# Altium schematic sheet LineFollower_2-0.SchDoc
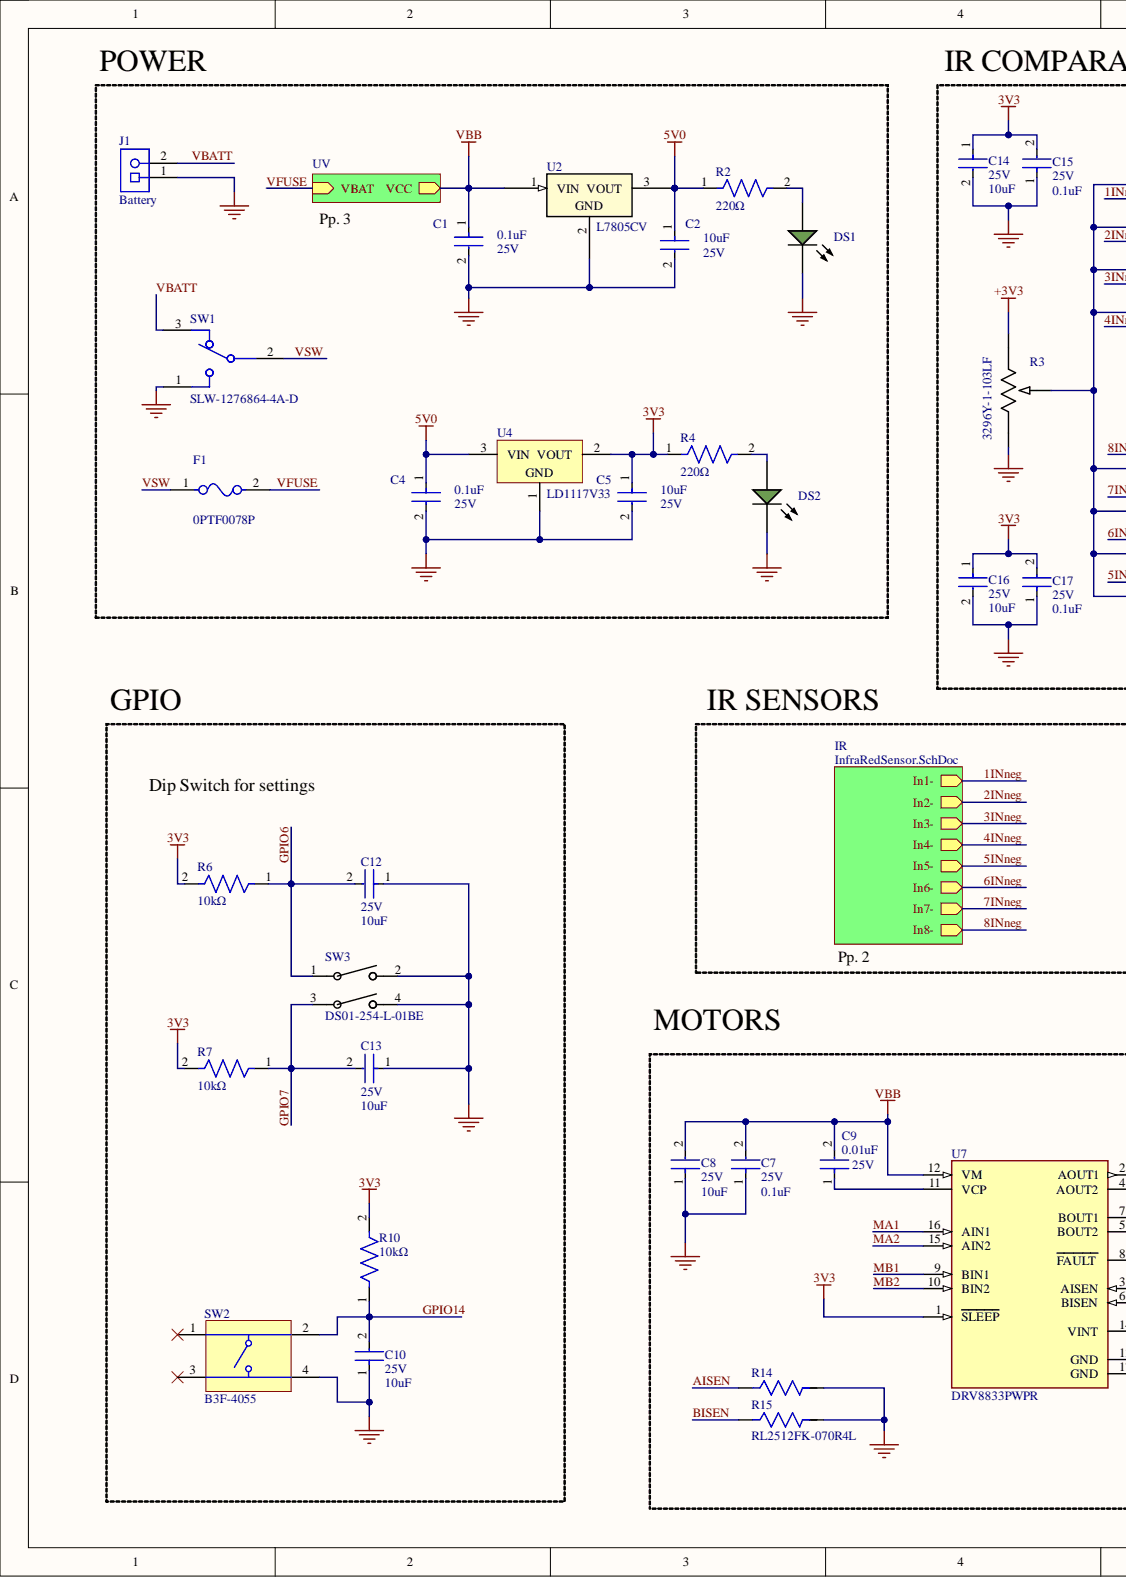
[diagram p0: LineFollower_2-0.SchDoc, left]
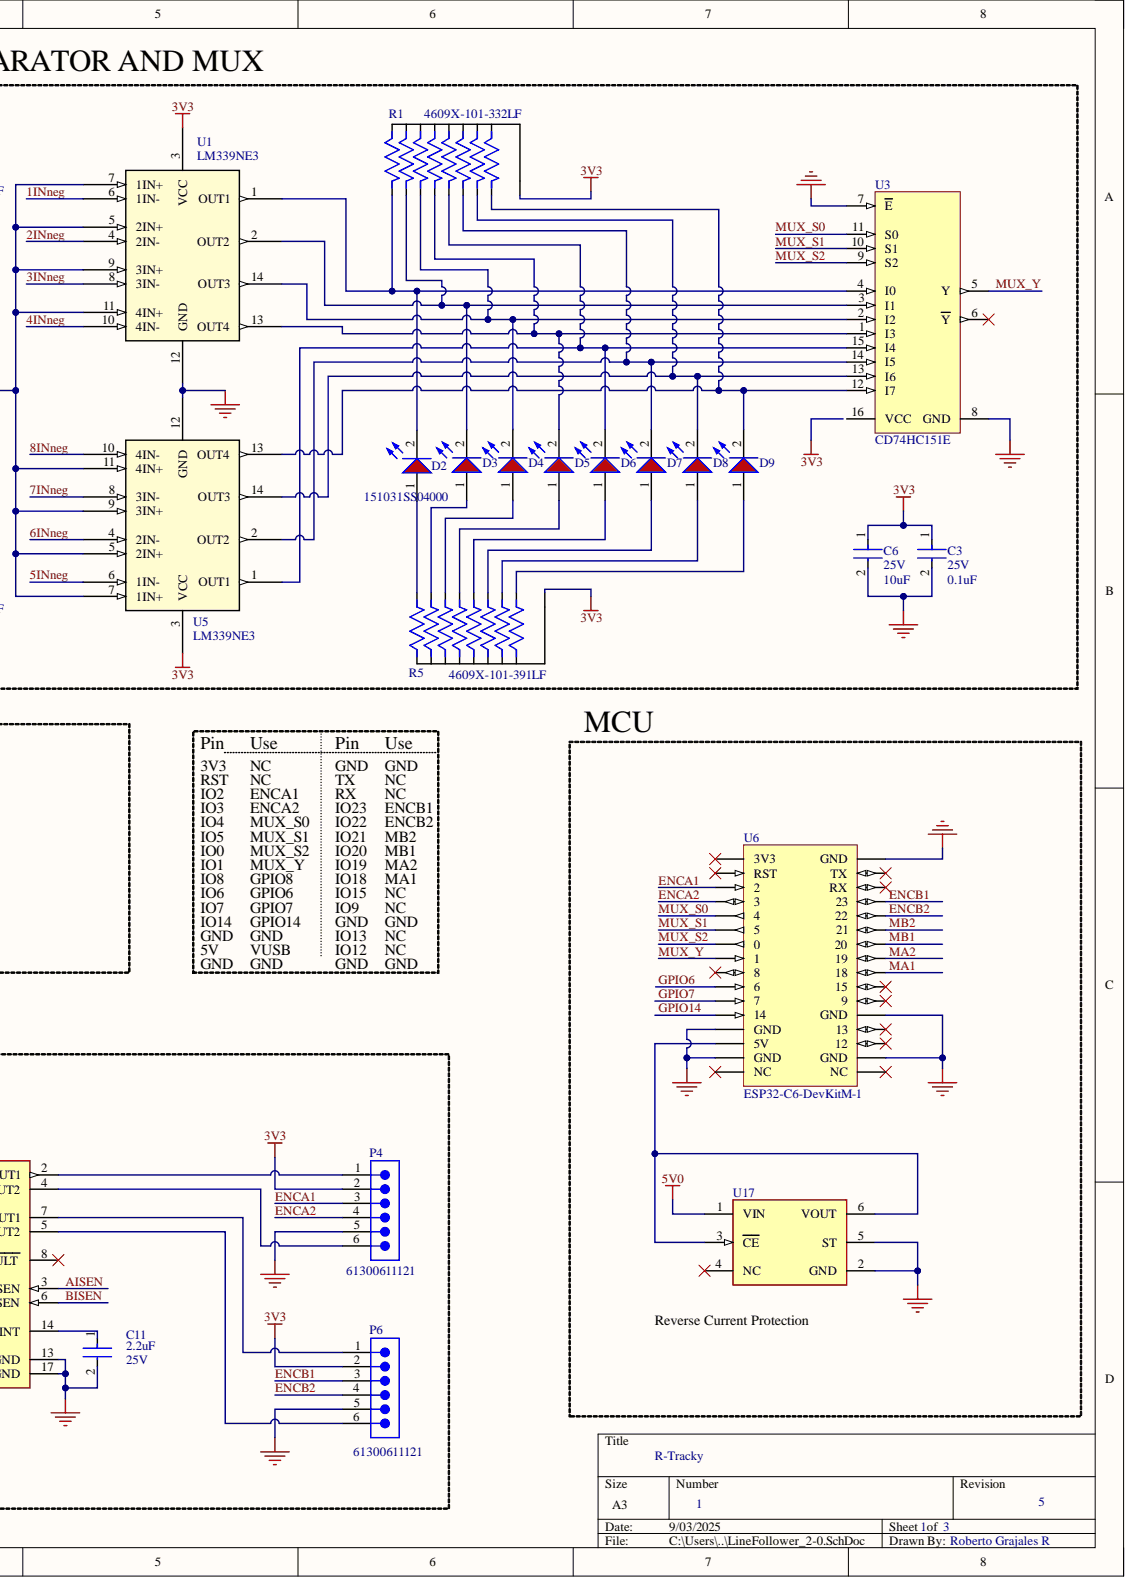
[diagram p1: LineFollower_2-0.SchDoc, right]

## Parts
C1  RCER71E106K3K1H03B  [FP-RCE3M1-MFG]  (value not drawn)
C2  Capacitance: 10uF  Voltage Rating: 25V  [FP-RCE3M1-MFG]  (hidden comment: RCER71E106K3K1H03B)
C3  Capacitance: 0.1uF  Voltage Rating: 25V  [FP-RCE3M1-MFG]  (hidden comment: RCER71E106K3K1H03B)
C4  RCER71E106K3K1H03B  [FP-RCE3M1-MFG]  (value not drawn)
C5  Capacitance: 10uF  Voltage Rating: 25V  [FP-RCE3M1-MFG]  (hidden comment: RCER71E106K3K1H03B)
C6  Capacitance: 10uF  Voltage Rating: 25V  [FP-RCE3M1-MFG]  (hidden comment: RCER71E106K3K1H03B)
C7  Capacitance: 0.1uF  Voltage Rating: 25V  [FP-RCE3M1-MFG]  (hidden comment: RCER71E106K3K1H03B)
C8  Capacitance: 10uF  Voltage Rating: 25V  [FP-RCE3M1-MFG]  (hidden comment: RCER71E106K3K1H03B)
C9  Capacitance: 0.01uF  Voltage Rating: 25V  [FP-RCE3M1-MFG]  (hidden comment: RCER71E106K3K1H03B)
C10  Capacitance: 10uF  Voltage Rating: 25V  [FP-RCE3M1-MFG]  (hidden comment: RCER71E106K3K1H03B)
C11  Capacitance: 2.2uF  Voltage Rating: 25V  [FP-RCE3M1-MFG]  (hidden comment: RCER71E106K3K1H03B)
C12  Capacitance: 10uF  Voltage Rating: 25V  [FP-RCE3M1-MFG]  (hidden comment: RCER71E106K3K1H03B)
C13  Capacitance: 10uF  Voltage Rating: 25V  [FP-RCE3M1-MFG]  (hidden comment: RCER71E106K3K1H03B)
C14  Capacitance: 10uF  Voltage Rating: 25V  [FP-RCE3M1-MFG]  (hidden comment: RCER71E106K3K1H03B)
C15  Capacitance: 0.1uF  Voltage Rating: 25V  [FP-RCE3M1-MFG]  (hidden comment: RCER71E106K3K1H03B)
C16  Capacitance: 10uF  Voltage Rating: 25V  [FP-RCE3M1-MFG]  (hidden comment: RCER71E106K3K1H03B)
C17  Capacitance: 0.1uF  Voltage Rating: 25V  [FP-RCE3M1-MFG]  (hidden comment: RCER71E106K3K1H03B)
D2  151031SS04000  [WL-TMRC_D3.95H5.3]
D3  151031SS04000  [WL-TMRC_D3.95H5.3]
D4  151031SS04000  [WL-TMRC_D3.95H5.3]
D5  151031SS04000  [WL-TMRC_D3.95H5.3]
D6  151031SS04000  [WL-TMRC_D3.95H5.3]
D7  151031SS04000  [WL-TMRC_D3.95H5.3]
D8  151031SS04000  [WL-TMRC_D3.95H5.3]
D9  151031SS04000  [WL-TMRC_D3.95H5.3]
DS1  LTL-1CHG  [LTST-LTL-1CHG-2]  (value not drawn)
DS2  LTL-1CHG  [LTST-LTL-1CHG-2]  (value not drawn)
F1  0PTF0078P  [FP-0PTF0078P-MFG]
J1  Battery  [PHOE-1729128-2_V]
P4  61300611121  [61300611121]
P6  61300611121  [61300611121]
R1  4609X-101-332LF  [BO_4609X]
R2  value 220Ω  [MyRes]  (hidden comment: 0.5 W Resistor)
R3  3296Y-1-103LF  [POT_3296Y]
R4  value 220Ω  [MyRes]  (hidden comment: 0.5 W Resistor)
R5  4609X-101-391LF  [BO_4609X]
R6  value 10kΩ  [MyRes]  (hidden comment: 0.5 W Resistor)
R7  value 10kΩ  [MyRes]  (hidden comment: 0.5 W Resistor)
R10  value 10kΩ  [MyRes]  (hidden comment: 0.5 W Resistor)
R14  RL2512FK-070R4L  [RES_2512_WSL-M]
R15  RL2512FK-070R4L  [RES_2512_WSL-M]
SW1  SLW-1276864-4A-D  [SLW-1276864_CUD]
SW2  B3F-4055  [OMRON-B3F-4055_V]
SW3  DS01-254-L-01BE  [SW_DS01-254-L-01BE_CUD]
U1  LM339NE3  [N14]
U2  L7805CV  [TO-220_STM]
U3  CD74HC151E  [N0016A]
U4  LD1117V33  [TO220]
U5  LM339NE3  [N14]
U6  ESP32-C6-DevKitM-1  [ESP32-C6-DevKitC-1]
U7  DRV8833PWPR  [FP-PWP0016C-IPC_A]
U17  LM66100DCKT  [FP-DCK0006A-IPC_C]  (value not drawn)

## Nets
3V3: C3.1 C5.1 C6.1 C14.1 C15.2 C16.1 C17.2 P4.2 P6.2 R1.1 R4.1 R5.1 R6.2 R7.2 R10.2 U1.3(VCC) U4.2 U5.3(VCC) U7.1(SLEEP)
5V0: C2.1 C4.1 R2.1 U2.3 U4.3 U17.1(VIN)
GND: C1.2 C2.2 C3.2 C4.2 C5.2 C6.2 C7.1 C8.1 C10.1 C11.2 C12.1 C13.1 C14.2 C15.1 C16.2 C17.1 DS1.2 DS2.2 J1.1 P4.5 P6.5 R3.3 R14.2 R15.2 SW1.1 SW2.4 SW3.2 SW3.4 U1.12(GND) U2.2 U3.7(E) U3.8(GND) U4.1 U5.12(GND) U6.13(GND) U6.15(GND) U6.18(GND) U6.21(GND) U6.32(GND) U7.13(GND) U7.17(GND) U17.2(GND) U17.5(ST)
VBB: C1.1 C7.2 C8.2 C9.2 U2.1 U7.12(VM)
1INneg: U1.6(1IN-)
2INneg: U1.4(2IN-)
3INneg: R3.1 U1.8(3IN-)
4INneg: U1.10(4IN-)
5INneg: U5.6(1IN-)
6INneg: U5.4(2IN-)
7INneg: U5.8(3IN-)
8INneg: U5.10(4IN-)
AISEN: R14.1 U7.3(AISEN)
BISEN: R15.1 U7.6(BISEN)
ENCA1: P4.3 U6.3
ENCA2: P4.4 U6.4
ENCB1: P6.3 U6.29
ENCB2: P6.4 U6.28
GPIO6: C12.2 R6.1 SW3.1 U6.10
GPIO7: C13.2 R7.1 SW3.3 U6.11
GPIO14: C10.2 R10.1 SW2.2 U6.12
MA1: U6.24 U7.16(AIN1)
MA2: U6.25 U7.15(AIN2)
MB1: U6.26 U7.9(BIN1)
MB2: U6.27 U7.10(BIN2)
MUX_S0: U3.11(S0) U6.5
MUX_S1: U3.10(S1) U6.6
MUX_S2: U3.9(S2) U6.7
MUX_Y: U3.5(Y) U6.8
VBATT: J1.2 SW1.3
VFUSE: F1.2
VSW: F1.1 SW1.2
NetC9_1: C9.1 U7.11(VCP)
NetC11_1: C11.1 U7.14(VINT)
NetD2_1: D2.1 R5.9
NetD2_2: D2.2 R1.9 U1.1(OUT1) U3.4(I0)
NetD3_1: D3.1 R5.8
NetD3_2: D3.2 R1.8 U1.2(OUT2) U3.3(I1)
NetD4_1: D4.1 R5.7
NetD4_2: D4.2 R1.7 U1.14(OUT3) U3.2(I2)
NetD5_1: D5.1 R5.6
NetD5_2: D5.2 R1.6 U1.13(OUT4) U3.1(I3)
NetD6_1: D6.1 R5.5
NetD6_2: D6.2 R1.5 U3.15(I4) U5.1(OUT1)
NetD7_1: D7.1 R5.4
NetD7_2: D7.2 R1.4 U3.14(I5) U5.2(OUT2)
NetD8_1: D8.1 R5.3
NetD8_2: D8.2 R1.3 U3.13(I6) U5.14(OUT3)
NetD9_1: D9.1 R5.2
NetD9_2: D9.2 R1.2 U3.12(I7) U5.13(OUT4)
NetDS1_1: DS1.1 R2.2
NetDS2_1: DS2.1 R4.2
NetP4_1: P4.1 U7.2(AOUT1)
NetP4_6: P4.6 U7.4(AOUT2)
NetP6_1: P6.1 U7.7(BOUT1)
NetP6_6: P6.6 U7.5(BOUT2)
NetR3_2: R3.2 U1.5(2IN+) U1.7(1IN+) U1.9(3IN+) U1.11(4IN+) U5.5(2IN+) U5.7(1IN+) U5.9(3IN+) U5.11(4IN+)
NetSW2_1: SW2.1
NetSW2_3: SW2.3
NetU3_6: U3.6(Y)
NetU3_16: U3.16(VCC)
NetU6_1: U6.1(3V3)
NetU6_2: U6.2(RST)
NetU6_9: U6.9
NetU6_14: U6.14(5V) U17.3(CE) U17.6(VOUT)
NetU6_16: U6.16(NC)
NetU6_17: U6.17(NC)
NetU6_19: U6.19
NetU6_20: U6.20
NetU6_22: U6.22
NetU6_23: U6.23
NetU6_30: U6.30(RX)
NetU6_31: U6.31(TX)
NetU7_8: U7.8(FAULT)
NetU17_4: U17.4(NC)

## Library data of the parts
C1 C5 C7 C9 C11 = CMP-06035-054025-1: Cap Ceramic 0.1uF 25V X7R ±10% Radial 5mm +125°C Automotive Ammo Pack; Lead Diameter: 0.5mm; Lead/Base Style: Formed Leads; Case/Package: Radial; Component Kind: Standard; Dielectric: X7R; Height: 5mm; Height - Seated (Max): 0.197inch; Lead Pitch: 0.197inch; Length: 0.217inch; Library Name: Altium Content Vault; Max Operating Temperature: 125°C; Min Operating Temperature: -55°C; Mount: Through Hole; Package Quantity: 500; Packaging: Bulk; Ratings: AEC-Q200; Resistor Type: Ceramic; Termination: Radial; Tolerance: 10%; Voltage Rating (DC): 25V; Width: 4mm
  C1: Capacitance: 0.1uF; Voltage Rating: 25V
C2 C3 C6 C8 C10 C12-C17 = CMP-06035-054025-1: Cap Ceramic 10uF 25V X7R ±10% Radial 5mm +125°C Automotive Ammo Pack; Lead Diameter: 0.5mm; Lead/Base Style: Formed Leads; Case/Package: Radial; Component Kind: Standard; Dielectric: X7R; Height: 5mm; Height - Seated (Max): 0.197inch; Lead Pitch: 0.197inch; Length: 0.217inch; Library Name: Altium Content Vault; Max Operating Temperature: 125°C; Min Operating Temperature: -55°C; Mount: Through Hole; Package Quantity: 500; Packaging: Bulk; Ratings: AEC-Q200; Resistor Type: Ceramic; Termination: Radial; Tolerance: 10%; Voltage Rating (DC): 25V; Width: 4mm
C4 = CMP-06035-054025-1: Cap Ceramic 0.15uF 25V X7R ±10% Radial 5mm +125°C Automotive Ammo Pack; Capacitance: 0.1uF; Lead Diameter: 0.5mm; Lead/Base Style: Formed Leads; Case/Package: Radial; Component Kind: Standard; Dielectric: X7R; Height: 5mm; Height - Seated (Max): 0.197inch; Lead Pitch: 0.197inch; Length: 0.217inch; Library Name: Altium Content Vault; Max Operating Temperature: 125°C; Min Operating Temperature: -55°C; Mount: Through Hole; Package Quantity: 500; Packaging: Bulk; Ratings: AEC-Q200; Resistor Type: Ceramic; Termination: Radial; Tolerance: 10%; Voltage Rating: 25V; Voltage Rating (DC): 25V; Width: 4mm
D2-D9 = CMP-1488-00005-2: NexarPartId: 29717802; Color: Red; Dominant Wavelength: 635nm; Forward Current: 100mA; Forward Voltage: 2V; Lens Size: 3mm; Lens Style: Circular, Diffused, Round; Luminous Intensity: 160mcd; Max Reverse Voltage (DC): 5V; Peak Wavelength: 640nm; Test Current: 20mA; Viewing Angle: 40°; Case/Package: Radial; Component Kind: Standard; Height: 5.3mm; Length: 3mm; Library Name: Altium Content Vault; Max Operating Temperature: 85°C; Min Operating Temperature: -40°C; Mount: Through Hole; Packaging: Bulk; REACH SVHC: Unknown; RoHS: Compliant; Wavelength: 635nm; Width: 3mm
DS1 DS2 = CMP-1674-00014-1: LITE-ON Electronic, INC, 120 mA, 100 mW, 5 V, -55 to 100 degC, 2-Pin THD, RoHS, Bulk; Case\Package: QFN; Interface: I2C; Max Supply Voltage: 3.6 V; Min Supply Voltage: 2.5 V; Component Kind: Standard; ComponentLink1Description: Manufacturer URL; ComponentLink1URL: http://www.us.liteon.com/; ComponentLink2Description: Datasheet; ComponentLink2URL: http://datasheet.octopart.com/LTL-1CHG-Lite-On-datasheet-143841.pdf; DatasheetVersion: Mar-00; Library Name: Altium Content Vault; Max Operating Temperature: 85 degC; Min Operating Temperature: -40 degC; Package Quantity: 5000; PackageDescription: 2-Pin Through Hole Device, Dia 3.8 mm; PackageReference: LTL-1CHG; Packaging: Cut Tape; Pins: 24; REACH SVHC: No SVHC; RoHSCompliant: true
F1 = CMP-08604-000023-1: FUSE BLOCK CARTRIDGE PCB; Manufacturer: Littelfuse Inc; Conn Orientation: Vertical; Fuse Current: 6.3A; Number Of Fuses: 1; Voltage Rating Ac: 250V; Ambient Temperature Range High: +85°C; Ambient Temperature Range Low: -30°C; Automotive: No; Category: Fuse; Component Kind: Standard; ComponentLink2Description: Datasheet; ComponentLink2URL: https://media.digikey.com/pdf/Data%20Sheets/Littelfuse%20PDFs/PTF075_77_78.pdf; Device Class L1: Circuit Protection; Device Class L2: Fuse Holders; Device Class L3: unset; Digikey Description: FUSE BLOCK CARTRIDGE PCB; Height: 11.5mm; Lead Free: Yes; Library Name: Altium Content Vault; Manufacturer Part Number: 0PTF0078P; Mouser Description: Fuse Holder 5X20MM Pitch 22/7 UL94V0 Open; Octopart: https://octopart.com/0ptf0078p-littelfuse-13255855; Package: 0; RoHS: TRUE; Voltage Rating Dc: 250V
J1 = CMP-2000-05815-1: PCB Terminal Block, 13.5 A, 400 V, 2-Pin THD, RoHS, Bulk; Manufacturer: Phoenix Contact; PartNumber: 1729128; Current Rating: 13500mA; No. of Positions: 2; No. of Rows: 1; Package Version: 03/2015; Pitch: 5.08mm; Voltage Rating: 400V; Component Kind: Standard; Datasheet URL: https://datasheet.ciiva.com/16046/1729128-16046181.pdf; Datasheet Version: 12/2013; Library Name: Altium Content Vault; Manufacturer URL: http://www.phoenixcontact.com/; Mounting Technology: ThroughHole; Orientation: Horizontal; Package Description: 2-Pin Through Hole Device, Body 8.1 x 10.16 mm, Pitch 5.08 mm; Package Reference: 1729128-2; Package URL: https://www.phoenixcontact.com/online/portal/pi/pxc/product_detail_page/!ut/p/b1/3VdJjqNKFDxLH8AFycySyWY2ozFsEPOMMQaDOX1XedGL_1Vdm5YXJleknhSKeBkvI6EA8ncAo3AYoDhBQGco6KN7VURTdemj9us_IEKEZo_YHiPAEWEFmDGOHMORkqKYCORBZxgL7ZoaZYcbFFUZ3fqxSY6GOuxydWUuHqz1ynmMFygu583Bob0Ewr6Sd_l-5m-kAueHiB0jpRwZwe58wwtkpxtMYd_LmGcDRU02dRNEyWt6Y2ti5pSyJ_reIkzIulrqApdnbT11V5jnCKbYNCvhEM1MCpPByat_X379-iThf08CI5GfOPqfBeS3BRoBOdD55AKVv0iLwCMq-2BrzXWSGRH7pUGD9uwXQjiph3xL0DKQwdGa0JImiIOmBNOestqgwTHSSs2oOep-78apyXQBvNi8ZLaFjvjWvcaUEBu0MC6Wbe-d6mB0FAebo2lUWinqYaZs5DAYzV0RP9yitUjnGJ0iI9xhZkT42OCQNUJJhkgWINNQLP2JFP6OpMg3JCVg70jqHT0lvKOnhHf0lPuOnnLf0VPuWx6_dxwUIvwk5WMkT0kLL1mh61l2yZVSzG3pYV_bqo-mj6uMKwKwdnnW8Jh5RTOJzMOA5c-eJDaZQw4mTMPH02PvS_ZAqNVjVTo2WyYLkwH_KU1mmmG5kjRhDqPXLwwVHvVOjCM60-fpXvTDfMGW1PVFVS1r4e4yVDk4ZdNbkUHvqPqQSeian4UD39fNKmachqdJ1NJbyk8rXT9mXTvPk3oE5meulKGgiruPJek-4I9hTT6G8ZLOyRSm2RRVbThERQad5OS28hdt4YW4vG0stgYYzsWsK4_7y6DZMjJSCqri1kyiZR5vD7pwIrNR7QwPHTcLTI5qT7eRlpHrdGiupYlqQDJ7zy_CND8fWWBoLRXxk1RVcXiBQwbTD4Xi7KL-FuO94t9xaqweXzH; Packing: Bulk; RoHS: TRUE
P4 P6 = CMP-1502-01070-3: NexarPartId: 20084590; Connector Type: Male Pin; Contact Resistance: 20mΩ; Current Rating: 3A; Gender: Male; Number of Contacts: 6; Operating Supply Voltage: 250V; Pitch: 2.54mm; Color: Black; Component Kind: Standard; Contact Gender: Male; Contact Material: Copper; Contact Mating Length: 5.9944mm; Contact Plating: Gold; Height: 8.89mm; Housing Material: PBT; Lead Free: Lead Free; Lead Pitch: 2.54mm; Library Name: Altium Content Vault; Max Operating Temperature: 105°C; Min Operating Temperature: -40°C; Mount: Through Hole; Number of Pins: 6; Number of Positions: 6; Number of Rows: 1; Orientation: Vertical; Packaging: Bulk; REACH SVHC: Unknown; RoHS: Compliant; Termination: Through Hole; Voltage Rating: 250V
R1 = 4609X-101-101LF: Array/Network Resistor, Bussed, Metal Glaze/thick Film, 1.13W, 100ohm, 100V, 2% +/-Tol, 100ppm/Cel, Through Hole Mount, 9010; Manufacturer: Bourns; Manufacturer Series: 4600X; Manufacturer_Name: Bourns Electronics; Channels: 1; Element Power Dissipation: 200mW; First Element Resistance: 100R; Lead Length: 3.3mm; Number of Elements: 8; Package Height: 8.89mm; Package Length: 22.81mm; Package Width: 2.49mm; Rated Temperature: 70°C; Temperature Coefficient (ppm/Cel): 100; Temperature Coefficient Tracking: 50ppm/°C; Value: 3k3R; Case/Package: 9010; Category: Resistor Arrays; Component Kind: Standard; ComponentLink1Description: https://datasheet.ciiva.com:443/pdfs/VipMasterIC/IC/BOUR/BOUR-S-A0008940916/BOUR-S-A0008940916-1.pdf?src-supplier=IHS+Markit; ComponentLink1URL: https://datasheet.ciiva.com:443/pdfs/VipMasterIC/IC/BOUR/BOUR-S-A0008940916/BOUR-S-A0008940916-1.pdf?src-supplier=IHS+Markit; ComponentLink2Description: https://datasheet.ciiva.com/11807/0900766b8069ccfd-11807166.pdf?src-supplier=Rs; ComponentLink2URL: https://datasheet.ciiva.com/11807/0900766b8069ccfd-11807166.pdf?src-supplier=Rs; ComponentLink3Description: https://datasheet.ciiva.com/30195/4600x-30195886.pdf?src-supplier=Onlinecomponents.com; ComponentLink3URL: https://datasheet.ciiva.com/30195/4600x-30195886.pdf?src-supplier=Onlinecomponents.com; ComponentLink4Description: https://datasheet.ciiva.com/14179/ee4609x-14179631.pdf?src-supplier=Distrelec; ComponentLink4URL: https://datasheet.ciiva.com/14179/ee4609x-14179631.pdf?src-supplier=Distrelec; ComponentLink5Description: https://datasheet.ciiva.com/1133/682196-1133199.pdf?src-supplier=Element14; ComponentLink5URL: https://datasheet.ciiva.com/1133/682196-1133199.pdf?src-supplier=Element14; Construction: Epoxy Resin; JESD-609 Code: e1; Lead Pitch: 2.54mm; Library Name: Schlib1.SchLib; Manufacturer Part Number: 4609X-101-101LF; Manufacturer_Part_Number: 4609X-101-101LF; Max Operating Temperature: 125°C; Min Operating Temperature: -55°C; Mounting Technology: Through Hole; Network Type: BUSSED; Package Shape: Rectangular; Packaging: Bulk; Pins: 9; Power: 1.13W; Pricing: 1=0.44, 10=0.28, 25=0.2372, 50=0.2104, 200=0.16815, 400=0.15163, 600=0.14315, 1000=0.13354, 5000=0.10982 (USD); Reach SVHC Compliant: Yes; Resistor Type: ARRAY/NETWORK RESISTOR; RoHS: Yes; RoHS Compliant: Yes; Series: 4600X; Stock: 1993; Supplier: Digikey; Supplier Part Number: 4609X-101-101LF-ND; Technology: METAL GLAZE/THICK FILM; Terminal Finish: Copper; Termination: Flat; Tolerance: 2%; Working Voltage: 100V; Copyright: Copyright (C) 2025 Ultra Librarian. All rights reserved.
R2 R4 R6 R7 R10 = RES: Watts: 0.5; Component Kind: Standard; Library Name: Schlib1.SchLib
R3 = 3296Y-1-103LF: No Description Available; Manufacturer_Name: Bourns Electronics; Component Kind: Standard; Library Name: Schlib1.SchLib; Manufacturer_Part_Number: 3296Y-1-103LF; Copyright: Copyright (C) 2025 Ultra Librarian. All rights reserved.
R5 = 4609X-101-101LF: Array/Network Resistor, Bussed, Metal Glaze/thick Film, 1.13W, 100ohm, 100V, 2% +/-Tol, 100ppm/Cel, Through Hole Mount, 9010; Manufacturer: Bourns; Manufacturer Series: 4600X; Manufacturer_Name: Bourns Electronics; Channels: 1; Element Power Dissipation: 200mW; First Element Resistance: 100R; Lead Length: 3.3mm; Number of Elements: 8; Package Height: 8.89mm; Package Length: 22.81mm; Package Width: 2.49mm; Rated Temperature: 70°C; Temperature Coefficient (ppm/Cel): 100; Temperature Coefficient Tracking: 50ppm/°C; Value: 180R; Case/Package: 9010; Category: Resistor Arrays; Component Kind: Standard; ComponentLink1Description: https://datasheet.ciiva.com:443/pdfs/VipMasterIC/IC/BOUR/BOUR-S-A0008940916/BOUR-S-A0008940916-1.pdf?src-supplier=IHS+Markit; ComponentLink1URL: https://datasheet.ciiva.com:443/pdfs/VipMasterIC/IC/BOUR/BOUR-S-A0008940916/BOUR-S-A0008940916-1.pdf?src-supplier=IHS+Markit; ComponentLink2Description: https://datasheet.ciiva.com/11807/0900766b8069ccfd-11807166.pdf?src-supplier=Rs; ComponentLink2URL: https://datasheet.ciiva.com/11807/0900766b8069ccfd-11807166.pdf?src-supplier=Rs; ComponentLink3Description: https://datasheet.ciiva.com/30195/4600x-30195886.pdf?src-supplier=Onlinecomponents.com; ComponentLink3URL: https://datasheet.ciiva.com/30195/4600x-30195886.pdf?src-supplier=Onlinecomponents.com; ComponentLink4Description: https://datasheet.ciiva.com/14179/ee4609x-14179631.pdf?src-supplier=Distrelec; ComponentLink4URL: https://datasheet.ciiva.com/14179/ee4609x-14179631.pdf?src-supplier=Distrelec; ComponentLink5Description: https://datasheet.ciiva.com/1133/682196-1133199.pdf?src-supplier=Element14; ComponentLink5URL: https://datasheet.ciiva.com/1133/682196-1133199.pdf?src-supplier=Element14; Construction: Epoxy Resin; JESD-609 Code: e1; Lead Pitch: 2.54mm; Library Name: Schlib1.SchLib; Manufacturer Part Number: 4609X-101-101LF; Manufacturer_Part_Number: 4609X-101-101LF; Max Operating Temperature: 125°C; Min Operating Temperature: -55°C; Mounting Technology: Through Hole; Network Type: BUSSED; Package Shape: Rectangular; Packaging: Bulk; Pins: 9; Power: 1.13W; Pricing: 1=0.44, 10=0.28, 25=0.2372, 50=0.2104, 200=0.16815, 400=0.15163, 600=0.14315, 1000=0.13354, 5000=0.10982 (USD); Reach SVHC Compliant: Yes; Resistor Type: ARRAY/NETWORK RESISTOR; RoHS: Yes; RoHS Compliant: Yes; Series: 4600X; Stock: 1993; Supplier: Digikey; Supplier Part Number: 4609X-101-101LF-ND; Technology: METAL GLAZE/THICK FILM; Terminal Finish: Copper; Termination: Flat; Tolerance: 2%; Working Voltage: 100V; Copyright: Copyright (C) 2025 Ultra Librarian. All rights reserved.
R14 R15 = RL2512FK-070R4L: Fixed Resistor, Metal Glaze/thick Film, 1W, 0.4ohm, 1% +/-Tol, -300,300ppm/Cel, 2512; Manufacturer: Yageo; Manufacturer_Name: Vishay; Manufacturer_Part_Number: WSL2512R2500FEA; Package Height: 0.55mm; Package Length: 6.35mm; Package Width: 3.2mm; Value: 400mR; Case/Package: 2512; Category: Chip SMD Resistors; Component Kind: Standard; ComponentLink1Description: https://datasheet.ciiva.com:443/pdfs/VipMasterIC/IC/YAGO/YAGO-S-A0001508779/YAGO-S-A0001508779-1.pdf?src-supplier=IHS+Markit; ComponentLink1URL: https://datasheet.ciiva.com:443/pdfs/VipMasterIC/IC/YAGO/YAGO-S-A0001508779/YAGO-S-A0001508779-1.pdf?src-supplier=IHS+Markit; ComponentLink2Description: http://www.mouser.com/ds/2/447/PYu-RL_521_RoHS_L_5-2927.pdf?src-supplier=Mouser; ComponentLink2URL: http://www.mouser.com/ds/2/447/PYu-RL_521_RoHS_L_5-2927.pdf?src-supplier=Mouser; ComponentLink3Description: http://www.yageo.com/documents/recent/PYu-RL_Group_521_RoHS_L_2.pdf?src-supplier=Digikey; ComponentLink3URL: http://www.yageo.com/documents/recent/PYu-RL_Group_521_RoHS_L_2.pdf?src-supplier=Digikey; Construction: Chip; Datasheet: https://www.vishay.com/doc?30100; JESD-609 Code: e3; Library Name: Schlib1.SchLib; Manufacturer Part Number: RL2512FK-070R4L; Max Operating Temperature: 155°C; Min Operating Temperature: -55°C; Packaging: Tape and Reel; Pins: 2; Power: 1W; Pricing: 1=0.28, 10=0.184, 100=0.1173, 1000=0.097 (USD); Reach SVHC Compliant: Yes; Resistor Type: FIXED RESISTOR; RoHS: Yes; RoHS Compliant: Yes; Series: RL; Stock: 7925; Supplier: Digikey; Supplier Part Number: 13-RL2512FK-070R4LCT-ND; Technology: METAL GLAZE/THICK FILM; Temperature Coefficient (ppm/Cel): -300,300; Terminal Finish: Nickel; Tolerance: 1%; Copyright: Copyright (C) 2025 Ultra Librarian. All rights reserved.
SW1 = SLW-1276864-4A-D: No Description Available; Mfr_Name: Same Sky; Component Kind: Standard; Library Name: Schlib1.SchLib; RefDes: RefDes; Type: Slide Switches
SW2 = CMP-2000-05902-1: Tactile Switch, 1 to 50 mA, 100 mOhm Max, 5 to 24 VDC, -25 to 70 degC, 4-Pin THD, RoHS, Bulk; Manufacturer: OmronElectronics; Actuator Color: Orange; Actuator Length: 3mm; Actuator Style: ProjectedPlunger; Body Orientation: Straight; Contact Rating @ Voltage: 0.05@24VDCA; Dielectric Strength: 500VAC; Electrical Life: 1000000Cycles; Ground Terminal: No; Illumination Type: N/R; Maximum DC Voltage Rating: 24V; Operating Force: 2.55N; Pole Throw Configuration: SPST; Product Weight: 0.85g; Switch Configuration: N.O.; Base Material: N/A; Component Kind: Standard; Contact Material: N/A; Contact Plating: Gold; Datasheet URL: https://datasheet.ciiva.com/20148/78-0136-20148640.pdf; Datasheet Version: 06/2009; Dimension: 12x12x7.3mm; Family: B3F; Housing Material: N/A; Library Name: Altium Content Vault; Manufacturer URL: http://www.omron.com/; Maximum AC Voltage Rating: N/A; Maximum Contact Resistance: 100mOhm; Mechanical Life: N/A; Minimum Insulation Resistance: 100MOhm; Mounting: ThroughHole,PCPins; Mounting Technology: ThroughHole; Operating Temperature: -25to70C; Package Description: 4-Pin Through Hole Device, Body 12 x 12 mm; Package Reference: B3F-4055; Packaging: Bag; Part No: B3F-4055; RoHS: Yes; Seal Description: N/A; Seal Location: N/A; Type: Miniature
SW3 = DS01-254-L-01BE: Switch DIP OFF ON SPST 1 Raised Slide 0.025A 24VDC PC Pins 2.54mm Thru-Hole Tube; Manufacturer: Same Sky; Category: DIP Switches; Component Kind: Standard; ComponentLink1Description: https://www.cuidevices.com/product/resource/digikeypdf/ds01-254.pdf?src-supplier=Digikey; ComponentLink1URL: https://www.cuidevices.com/product/resource/digikeypdf/ds01-254.pdf?src-supplier=Digikey; ComponentLink2Description: https://datasheet.octopart.com/DS01-254-L-01BE-Same-Sky-datasheet-182049626.pdf?src-supplier=Future+Electronics; ComponentLink2URL: https://datasheet.octopart.com/DS01-254-L-01BE-Same-Sky-datasheet-182049626.pdf?src-supplier=Future+Electronics; ComponentLink3Description: https://datasheet.octopart.com/DS01-254-L-01BE-Same-Sky-datasheet-182880573.pdf?src-supplier=Electro+Sonic; ComponentLink3URL: https://datasheet.octopart.com/DS01-254-L-01BE-Same-Sky-datasheet-182880573.pdf?src-supplier=Electro+Sonic; ComponentLink4Description: https://datasheet.octopart.com/DS01-254-L-01BE-Same-Sky-datasheet-188930655.pdf?src-supplier=TME; ComponentLink4URL: https://datasheet.octopart.com/DS01-254-L-01BE-Same-Sky-datasheet-188930655.pdf?src-supplier=TME; ComponentLink5Description: https://datasheet.octopart.com/DS01-254-L-01BE-Same-Sky-datasheet-189104861.pdf?src-supplier=Master+Electronics; ComponentLink5URL: https://datasheet.octopart.com/DS01-254-L-01BE-Same-Sky-datasheet-189104861.pdf?src-supplier=Master+Electronics; Library Name: Schlib1.SchLib; Manufacturer Part Number: DS01-254-L-01BE; Manufacturer_Name: Same Sky; Manufacturer_Part_Number: DS01-254-L-01BE; Pricing: 1=0.42, 10=0.36, 25=0.3364, 100=0.3011, 250=0.27896, 500=0.26326, 1920=0.23602, 3840=0.22379, 5760=0.21721 (USD); RoHS: No; Stock: 13531; Supplier: Digikey; Supplier Part Number: 2223-DS01-254-L-01BE-ND; Copyright: Copyright (C) 2025 Ultra Librarian. All rights reserved.
U1 U5 = LM339NE3: Quad differential comparator 14-PDIP 0 to 70; Manufacturer: Texas Instruments; Input Bias Current: 50nA; Input Offset Voltage (Vos): 5mV; Max Dual Supply Voltage: 15V; Max Input Current: 250nA; Max Supply Current: 2mA; Max Supply Voltage: 30V; Min Dual Supply Voltage: 1V; Min Supply Voltage: 2V; Number of Channels: 4; Output Current: 20mA; Output Type: TTL; Quiescent Current: 2.5mA; Response Time: 0.3us; Supply Current: 500uA; Voltage Gain: 106.02dB; Case/Package: PDIP; Category: Amplifiers - Op Amps, Buffer, Instrumentation; Component Kind: Standard; ComponentLink1Description: https://datasheet.ciiva.com/1829/lm139a-1829496.pdf?src-supplier=Digikey; ComponentLink1URL: https://datasheet.ciiva.com/1829/lm139a-1829496.pdf?src-supplier=Digikey; ComponentLink2Description: https://datasheet.ciiva.com/2971/lm2901-2971903.pdf?src-supplier=Avnet; ComponentLink2URL: https://datasheet.ciiva.com/2971/lm2901-2971903.pdf?src-supplier=Avnet; Lead Free: Lead Free; Library Name: LM339NE3.SchLib; Manufacturer Part Number: LM339NE3; Max Operating Temperature: 70°C; Mfr_Name: Texas Instruments; Min Operating Temperature: 0°C; Mounting Technology: Through Hole; Number of Elements: 4; Package Quantity: 25; Packaging: Rail/Tube; Pins: 14; Pricing: 1=0.36, 10=0.248, 50=0.221, 100=0.191, 1000=0.166, 10000=0.166 (USD); Radiation Hardening: No; RefDes: RefDes; RoHS: Yes; RoHS Compliant: Yes; Series: LM339; Stock: 2931; Supplier: Mouser; Supplier Part Number: 595-LM339NE3; Technology: BIPOLAR; Type: Type
U2 = L7805CV: Voltage Regulator; linear; fixed; Vout: 5V; Vin: 7-35V; Vd: 2V; 2%; 1A; 0+150 deg.C; THT; TO220; Manufacturer: STMicroelectronics; Max Output current: 1.5A; Max Supply Voltage: 35V; Min Input Voltage: 7V; Min Output Voltage: 4.65V; Number of Channels: 1; Polarity: Positive; Power Supply Rejection Ratio (PSRR): 62dB; Quiescent Current: 8mA; Tolerance: 5%; Case/Package: TO-220; Category: Voltage Regulators - Linear; Component Kind: Standard; Contact Plating: Gold; Dropout Voltage: 2V; Height: 9.15mm; Lead Free: Lead Free; Length: 10.4mm; Library Name: L7805CV.SchLib; Manufacturer Part Number: L7805CV; Max Operating Temperature: 125°C; Mfr_Name: STMicroelectronics; Min Operating Temperature: -40°C; Mounting Technology: Through Hole; Nominal Output Voltage: 5V; Number of Outputs: 1; Number of Regulators: 1; Output Current: 1.5A; Output Type: Fixed; Output Voltage: 5V; Pins: 3; Pricing: 1=0.5269, 10=0.3094, 100=0.2572, 500=0.2562, 1000=0.2355, 2500=0.2156, 10000=0.1943, 25000=0.1111 (USD); Radiation Hardening: No; Reach SVHC Compliant: No; RefDes: RefDes; RoHS: No; Stock: 623890; Supplier: Arrow Electronics; Supplier Part Number: L7805CV; Type: Type; Weight: 2.299997g; Width: 4.6mm
U3 = CMP-1633-00701-2: High Speed CMOS Logic 8-Input Multiplexer, N0016A, TUBE; Manufacturer: Texas Instruments; Bits(#): 8; Channels(#): 1; Configuration: 8：1; F @ Nom Voltage(Max)(Mhz): 28; Function: Encoder/Multiplexer; ICC @ Nom Voltage(Max)(mA): 0.08; Input Type: LVTTL/CMOS; Output Drive (IOL/IOH)(Max)(mA): 5.2/-5.2; Rating: Catalog; Schmitt Trigger: No; Technology Family: HC; tpd @ Nom Voltage(Max)(ns): 43; VCC(Max)(V): 6; VCC(Min)(V): 2; Voltage(Nom)(V): 3.3,5; Code_JEDEC: MS-001; Component Kind: Standard; DatasheetVersion: SCHS150C; Generic Part Number: CD74HC151; Library Name: Altium Content Vault; Operating Temperature Range(C): -55 to 125; Output Type: CMOS; PackageReference: N0016A; Type: Standard
U4 = CMP-0244-00470-1: Fixed Low Drop Positive Voltage Regulator, 3.3V, 3-Pin TO-220; Component Kind: Standard; ComponentLink1Description: Manufacturer URL; ComponentLink1URL: http://www.st.com; ComponentLink2Description: Datasheet; ComponentLink2URL: http://www.st.com/internet/com/TECHNICAL_RESOURCES/TECHNICAL_LITERATURE/DATASHEET/CD00000544.pdf; ComponentLink3Description: Package Specification; ComponentLink3URL: http://www.st.com/internet/com/TECHNICAL_RESOURCES/TECHNICAL_LITERATURE/PACKAGE_INFORMATION/CD00004649.pdf; DatasheetVersion: 1/11/2011; Library Name: Altium Content Vault; PackageDescription: TO, Thru-Hole, Vertical, Heatsink Mounted; 3 In-Line Leads; Pitch 2.54 mm; PackageReference: TO220; PackageVersion: ver3.0
U6 = ESP32-C6-DevKitM-1: Dev tool for module, ESP32-S3-WROOM-1-N8; Manufacturer: Espressif Systems; Manufacturer Part Number: ESP32-S3-DEVKITC-1-N8; Category: RF Semiconductors and Devices; Component Kind: Standard; ComponentLink1Description: https://datasheet.octopart.com/ESP32-S3-DEVKITC-1-N8-Espressif-Systems-datasheet-165560559.pdf?src-supplier=TME; ComponentLink1URL: https://datasheet.octopart.com/ESP32-S3-DEVKITC-1-N8-Espressif-Systems-datasheet-165560559.pdf?src-supplier=TME; Library Name: Schlib1.SchLib; Pricing: 1=15, 10=15, 50=15, 100=15, 1000=15, 10000=15 (USD); RoHS: No; Stock: 29; Supplier: Mouser; Supplier Part Number: 356-ESP32-S3DVKTC1N8
U7 = CMP-1529-00004-3: IC MOTOR DRIVER PAR 16HTSSOP; Fault Protection: Over Temperature; Interface: PWM; Max Output Current: 2A; Max Supply Voltage: 10.8V; Min Supply Voltage: 2.7V; Nominal Supply Current: 3mA; Number of Outputs: 2; Output Current: 1.5A; Case/Package: HTSSOP; Component Kind: Standard; Lead Free: Lead Free; Library Name: Altium Content Vault; Max Operating Temperature: 85°C; Min Operating Temperature: -40°C; Number of Pins: 16; Output Current per Channel: 1.5A; Package Quantity: 2000; Packaging: Tape and Reel; Radiation Hardening: No; REACH SVHC: No SVHC; RMS Current (Irms): 1.5A; RoHS Compliant: Yes; Series: DRV8833; Supply Current: 3mA; Technology: NMOS
U17 = CMP-04910-000059-1: IC OR CTRLR IDEAL DIODE SC70-6; Component Kind: Standard; Library Name: Altium Content Vault
